# Altium schematic sheet WirelessEarBuds_Amp.SchDoc
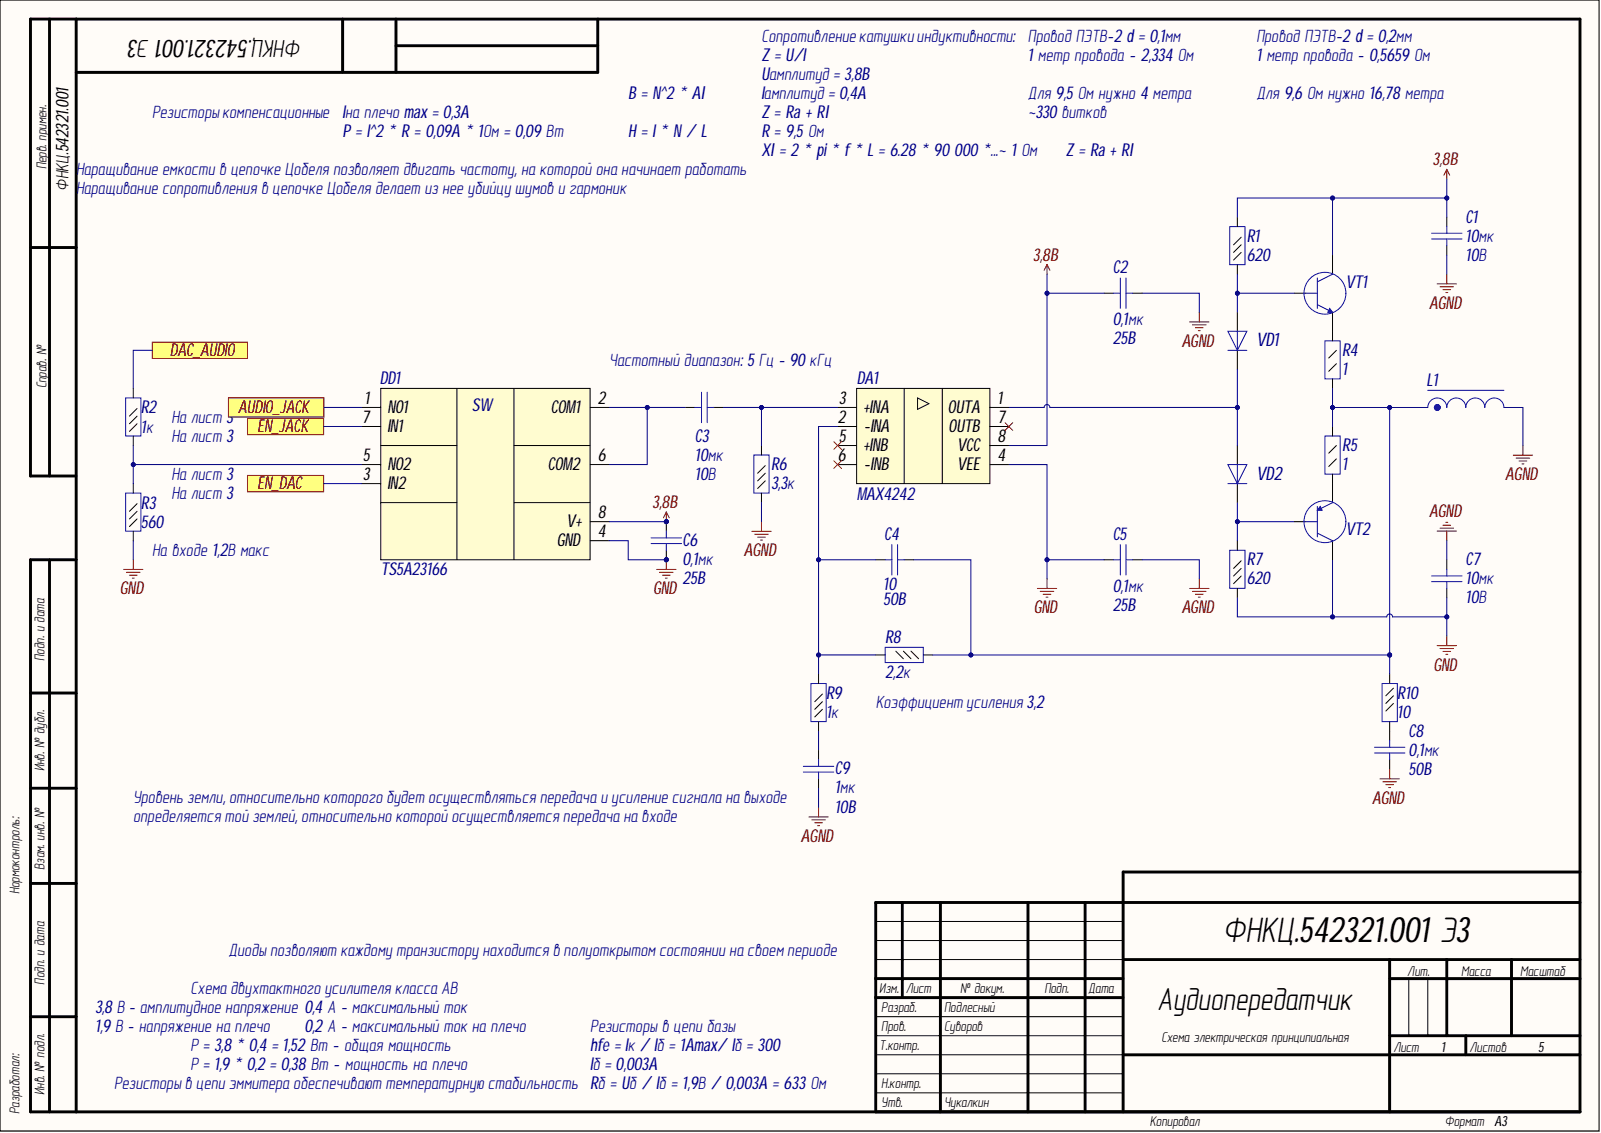
[diagram p0: WirelessEarBuds_Amp.SchDoc]

## Parts
C1  value 10мк  Voltage: 10В  [C0805]  (hidden comment: Capacitor)
C2  value 0,1мк  Voltage: 25B  [C0402]  (hidden comment: Capacitor)
C3  value 10мк  [C0805]  (hidden comment: Capacitor)
C4  value 10  Voltage: 50B  [C0402]  (hidden comment: Capacitor)
C5  value 0,1мк  Voltage: 25B  [C0402]  (hidden comment: Capacitor)
C6  value 0,1мк  Voltage: 25B  [C0402]  (hidden comment: Capacitor)
C7  value 10мк  Voltage: 10В  [C0805]  (hidden comment: Capacitor)
C8  value 0,1мк  Voltage: 50B  [C0402]  (hidden comment: Capacitor)
C9  value 1мк  Voltage: 10B  [C0402]  (hidden comment: Capacitor)
DA1  MAX4242  [8µMAX]
DD1  TS5A23166  [US8]
L1  InductorSerd  [InductorPetlya]  (value not drawn)
R1  value 620  [R0402]  (hidden comment: Resistor_0,062Вт)
R2  value 1к  [R0402]  (hidden comment: Resistor_0,062Вт)
R3  value 560  [R0402]  (hidden comment: Resistor_0,062Вт)
R4  value 1  [R0805]  (hidden comment: Resistor_0,125Вт)
R5  value 1  [R0805]  (hidden comment: Resistor_0,125Вт)
R6  value 3,3к  [R0402]  (hidden comment: Resistor_0,062Вт)
R7  value 620  [R0402]  (hidden comment: Resistor_0,062Вт)
R8  value 2,2к  [R0402]  (hidden comment: Resistor_0,062Вт)
R9  value 1к  [R0402]  (hidden comment: Resistor_0,062Вт)
R10  value 10  [R0402]  (hidden comment: Resistor_0,062Вт)
VD1  Diode  [SOD-323]  (value not drawn)
VD2  Diode  [SOD-323]  (value not drawn)
VT1  BJT NPN  [SOT-223]  (value not drawn)
VT2  BJT PNP  [SOT-223]  (value not drawn)

## Ports
AUDIO_JACK, DAC_AUDIO, EN_DAC, EN_JACK

## Nets
3,8B: C1.2 C2.2 C6.1 DA1.8(VCC) DD1.8(V+) R1.2 VT1.2
AGND: C1.1 C2.1 C5.1 C7.2 C8.1 C9.1 L1.2 R6.1
GND: C5.2 C6.2 C7.1 DA1.4(VEE) DD1.4(GND) R3.1 R7.1 VT2.2
AUDIO_JACK: DD1.1(NO1) ; port AUDIO_JACK
DAC_AUDIO: R2.2 ; port DAC_AUDIO
EN_DAC: DD1.3(IN2) ; port EN_DAC
EN_JACK: DD1.7(IN1) ; port EN_JACK
NetC3_1: C3.1 DD1.2(COM1) DD1.6(COM2)
NetC3_2: C3.2 DA1.3(+INA) R6.2
NetC4_1: C4.1 DA1.2(-INA) R8.2 R9.2
NetC4_2: C4.2 L1.1 R4.1 R5.2 R8.1 R10.1
NetC8_2: C8.2 R10.2
NetC9_2: C9.2 R9.1
NetDA1_1: DA1.1(OUTA) VD1.1 VD2.2
NetDD1_5: DD1.5(NO2) R2.1 R3.2
NetR1_1: R1.1 VD1.2 VT1.1
NetR4_2: R4.2 VT1.3
NetR5_1: R5.1 VT2.3
NetR7_2: R7.2 VD2.1 VT2.1

Not connected: DA1 5(+INB) 6(-INB) 7(OUTB)

## Library data of the parts
C1 C7 = cap201: Конденсатор; Manufacture: Murata; Part Name: Ceramic; Part Number: GRM21BR71A106KE51L; Database Table Name: Capacitors$; TKE: X7R; Component Kind: Standard; id: cap201; Library Name: MOP44.DbLib
C2 C5 C6 = cap52: Конденсатор; Manufacture: Murata; Part Name: Ceramic; Part Number: GRM155F51E104Z**; Database Table Name: Capacitors$; TKE: Y5V; Component Kind: Standard; id: cap52; Library Name: MOP44.DbLib
C3 = cap201: Конденсатор; Manufacture: Murata; Part Name: Ceramic; Part Number: GRM21BR71A106KE51L; Database Table Name: Capacitors$; TKE: X7R; Voltage: 10В; Component Kind: Standard; id: cap201; Library Name: MOP44.DbLib
C4 C8 = cap5: Конденсатор; Manufacture: Murata; Part Name: Ceramic; Part Number: GRM1535C1H100J; Database Table Name: Capacitors$; TKE: NP0; Component Kind: Standard; id: cap5; Library Name: MOP44.DbLib
C9 = cap55: Конденсатор; Manufacture: Murata; Part Name: Ceramic; Part Number: GRM155R61A105K; Database Table Name: Capacitors$; TKE: Y5V; Component Kind: Standard; id: cap55; Library Name: MOP44.DbLib
DA1 = amp13: МИКРОМОЩНЫЙ Single/Dual/Quad, +1.8V/10µA, SOT23,  Beyond-the-Rails Op Amps; Manufacture: Maxim Integrated; Part Name: Micropower Operational Amplifiers; Part Number: MAX4242EUA; Bandwidth: 90kHz; Channels: 1; CMRR: 90dB; Database Table Name: Amplifier$; Input Bias Current: (+-)2,3nA; Offset voltage: (+-)0,2mB; PSRR: 85dB; Rail-to-rail: yes; Supply Current max: 10uA; Supply Voltage: 1,8В–5,5В or (+-)0,9В-(+-)2,75B; Component Kind: Standard; id: amp13; Library Name: MOP44.DbLib
DD1 = logic11: Dual-SPST Analog Switch; Manufacture: Texas Instruments; Charge Injection: 6pC; Database Table Name: Logic$; Logic High Level: 1,5-5,5B; Logic Low Level: 0,6B; Number of elements: 2; Power Supply: 1,65В-5,5B; Resistance of channel: 1,1Ohm; Toff: 5ns; Ton: 8,5ns; Type of Logic: CMOS; Component Kind: Standard; id: logic11; Library Name: MOP44.DbLib; Part Name: Dual-SPST Analog Switch; Part Number: TS5A23166
L1 = ind67: EMI Suppression Filter; Part Name: My own inductor for petlya; Database Table Name: Inductor$; Component Kind: Standard; id: ind67; Library Name: MOP44.DbLib
R1 R7 = res261: Резистор; Manufacture: Yageo; Part Number: RC0402FR-07620RL; Accuracy: 1%; Database Table Name: Resistor$; Component Kind: Standard; id: res261; Library Name: MOP44.DbLib; Part Name: Resistor; Power: 0,062Вт
R2 R3 R6 R8-R10 = res278: Резистор; Manufacture: Yageo; Part Number: RC0402FR-072K3; Accuracy: 1%; Database Table Name: Resistor$; Component Kind: Standard; id: res278; Library Name: MOP44.DbLib; Part Name: Resistor; Power: 0,062Вт
R4 R5 = res1: Резистор; Manufacture: Yageo; Part Number: RC0805FR-071RL; Accuracy: 1%; Database Table Name: Resistor$; Component Kind: Standard; id: res1; Library Name: MOP44.DbLib; Part Name: Resistor; Power: 0,125Вт
VD1 VD2 = diode18: Диод; Manufacture: NXP Semiconductor; Part Number: BAS321.115; Breakdown Current: 100nA; Capacitance: 2pF; Database Table Name: Diode$; Forward Current: 0,25А; Forward Voltage: 1,25В; Max Reverse Voltage: 250В; Number of Channels: 1; Reverse Recovery time: 50ns; Component Kind: Standard; id: diode18; Library Name: MOP44.DbLib; Part Name: Diode
VT1 = bjt3: Manufacture: NXP Semiconductor; Part Name: Transistor; Part Number: PBSS4540Z.115; Database Table Name: BJTTransistor$; Frequency: 130МГц; hfe: 300; Ic max: 5А; Power: 2Вт; Vceo max: 40В; Component Kind: Standard; id: bjt3; Library Name: MOP44.DbLib; Structure: npn
VT2 = bjt4: Manufacture: NXP Semiconductor; Part Name: Transistor; Part Number: PBSS5540Z.115; Database Table Name: BJTTransistor$; Frequency: 130МГц; hfe: 300; Ic max: 5А; Power: 2Вт; Vceo max: 40В; Component Kind: Standard; id: bjt4; Library Name: MOP44.DbLib; Structure: pnp
